# Altium schematic sheet main.SchDoc
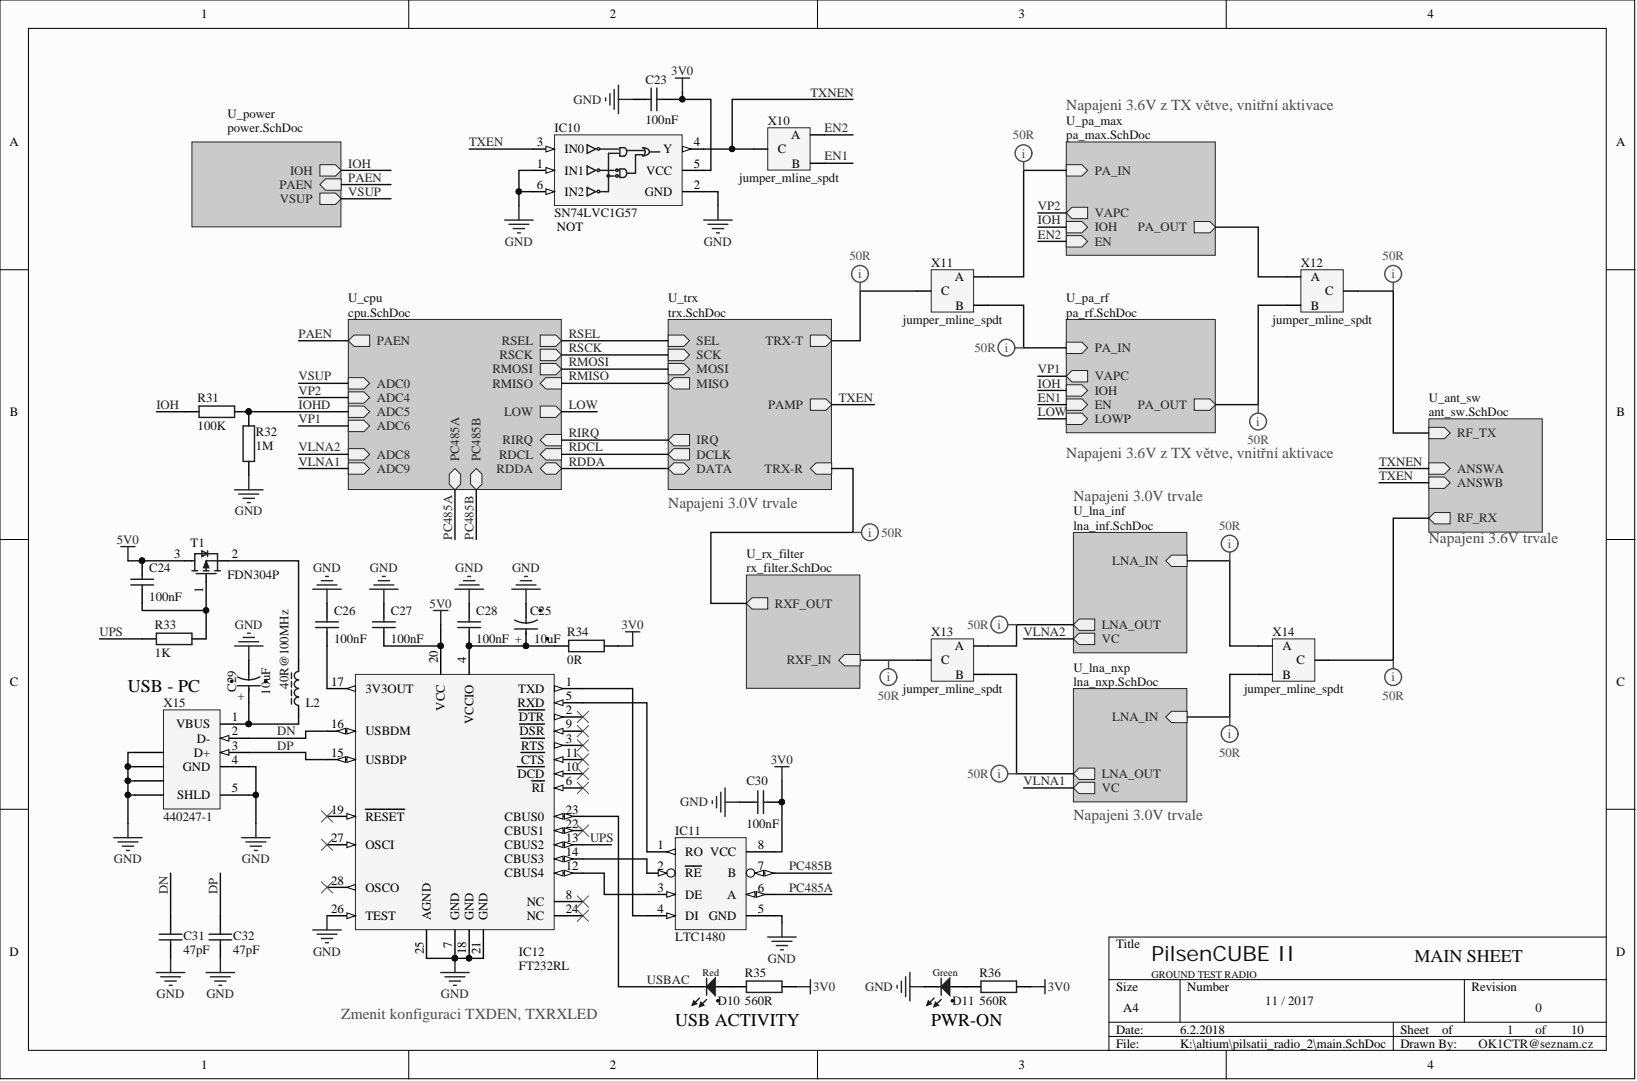
[diagram p0: main.SchDoc]

## Parts
C23  100nF  [C_0603]
C24  100nF  [C_0603]
C25  10uF  [CAPMP3216X180N]
C26  100nF  [C_0603]
C27  100nF  [C_0603]
C28  100nF  [C_0603]
C29  10uF  [CAPMP3216X180N]
C30  100nF  [C_0603]
C31  47pF  [C_0603]
C32  47pF  [C_0603]
D10  Red  [LED_DIALIGHT_551-1107F]
D11  Green  [LED_DIALIGHT_551-1307F]
IC10  SN74LVC1G57  [TSOP65P210X110-6N]
IC11  LTC1480  [SO-8_L]
IC12  FT232RL  [SSOP-28_L]
L2  40R@100MHz  [INDC2012X08N]
R31  100K  [R_0603]
R32  1M  [R_0603]
R33  1K  [R_0603]
R34  0R  [R_0603]
R35  560R  [R_0603]
R36  560R  [R_0603]
T1  FDN304P  [SOT95P251X93-3N]
X10  jumper_mline_spdt  [SPDT_MJMP_011]
X11  jumper_mline_spdt  [SPDT_MJMP_011]
X12  jumper_mline_spdt  [SPDT_MJMP_011]
X13  jumper_mline_spdt  [SPDT_MJMP_011]
X14  jumper_mline_spdt  [SPDT_MJMP_011]
X15  440247-1  [440247]

## Nets
3V0: C23.2 C30.2 IC10.5(VCC) IC11.8(VCC) R34.1 R35.1 R36.2
5V0: C24.1 C27.2 IC12.20(VCC) T1.3
GND: C23.1 C25.2 C26.1 C27.1 C28.1 C29.2 C30.1 C31.1 C32.1 D11.1 IC10.1(IN1) IC10.2(GND) IC10.6(IN2) IC11.5(GND) IC12.7(GND) IC12.18(GND) IC12.21(GND) IC12.25(AGND) IC12.26(TEST) R32.1 X15.4(GND) X15.5(SHLD) X15.6 X15.7 X15.8 X15.9
DN: C31.2 IC12.16(USBDM) X15.2(D-)
DP: C32.2 IC12.15(USBDP) X15.3(D+)
EN1: X10.2
EN2: X10.1
IOH: R31.2
IOHD: R31.1 R32.2
PC485A: IC11.6(A)
PC485B: IC11.7(B)
TXEN: IC10.3(IN0)
TXNEN: IC10.4(Y) X10.3
UPS: IC12.13(CBUS2) R33.2
USBAC: D10.1 IC12.23(CBUS0)
NetC24_2: C24.2 R33.1 T1.1
NetC25_1: C25.1 C28.2 IC12.4(VCCIO) R34.2
NetC26_2: C26.2 IC12.17(3V3OUT)
NetC29_1: C29.1 L2.1 X15.1(VBUS)
NetD10_2: D10.2 R35.2
NetD11_2: D11.2 R36.1
NetIC11_1: IC11.1(RO) IC12.5(RXD)
NetIC11_2: IC11.2(RE) IC12.14(CBUS3)
NetIC11_3: IC11.3(DE) IC12.12(CBUS4)
NetIC11_4: IC11.4(DI) IC12.1(TXD)
NetIC12_2: IC12.2(DTR)
NetIC12_3: IC12.3(RTS)
NetIC12_6: IC12.6(RI)
NetIC12_8: IC12.8(NC)
NetIC12_9: IC12.9(DSR)
NetIC12_10: IC12.10(DCD)
NetIC12_11: IC12.11(CTS)
NetIC12_19: IC12.19(RESET)
NetIC12_22: IC12.22(CBUS1)
NetIC12_24: IC12.24(NC)
NetIC12_27: IC12.27(OSCI)
NetIC12_28: IC12.28(OSCO)
NetL2_2: L2.2 T1.2
NetX11_1: X11.1
NetX11_2: X11.2
NetX11_3: X11.3
NetX12_1: X12.1
NetX12_2: X12.2
NetX12_3: X12.3
NetX13_1: X13.1
NetX13_2: X13.2
NetX13_3: X13.3
NetX14_1: X14.1
NetX14_2: X14.2
NetX14_3: X14.3
LOW:
PAEN:
RDCL:
RDDA:
RIRQ:
RMISO:
RMOSI:
RSCK:
RSEL:
RXF_OUT:
VLNA1:
VLNA2:
VP1:
VP2:
VSUP:

## Library data of the parts
C23 C24 C26-C28 C30-C32 = C: Generic Chip Capacitor; Type: SMT; Component Kind: Standard; PackageReference: C_0603; Published: 16.1.2018; Library Name: libctr2_capacitors.IntLib; Publisher: OK1CTR
  C23 C24 C26-C28 C30: Value: 100nF
  C31 C32: Value: 47pF
C25 C29 = CTE3216-18_10UF_10V_10%_LESR1800: Polarised capacitor; Manufacturer: GENERIC; Database Table Name: Capacitors SMD; Type: SMT; Case: A; Component Kind: Standard; Library Name: Capacitors.DbLib; Manuf Part Number: CTE3216-18_10UF_10V_10%_LESR1800; Published: 16.1.2018; Value: 10uF; Author: CERN DEM JLC; Publisher: OK1CTR
D10 = LED_DIALIGHT_551-1107F: LED Red 1C 2A; Database Table Name: LEDs & Displays; Type: THT; Color: Red; Component Kind: Standard; Library Name: ICs And Semiconductors.DbLib; Manufacturer: DIALIGHT; Manuf Part Number: 551-1107F; PackageReference: LED 3mm; Published: 16.1.2018; Value: Red; Author: CERN DEM JLC; Publisher: OK1CTR
D11 = LED_DIALIGHT_551-1307F: LED Green 1C 2A; Database Table Name: LEDs & Displays; Type: THT; Color: Green; Component Kind: Standard; Library Name: ICs And Semiconductors.DbLib; Manufacturer: DIALIGHT; Manuf Part Number: 551-1307F; PackageReference: LED 3mm; Published: 16.1.2018; Value: Green; Author: CERN DEM JLC; Publisher: OK1CTR
IC10 = (no symbol name): Configurable Multiple-Function Gate; Manufacturer: Texas Instruments; Manuf Part Number: SN74LVC1G57DCKR; Supp Part number: 1470833; Component Kind: Standard; ComponentLink1Description: Datasheet; Library Name: radio_power.SchLib; ManufacturerURL: http://www.ti.com/; PackageReference: SC-70; Published: 16.1.2018; Supplier: Farnell; Supplier2: N/A; Supplier3: N/A; SupplierURL: http://cz.farnell.com/; SupplierURL2: N/A; SupplierURL3: N/A; Supp Part number 2: N/A; Supp Part number 3: N/A; Type: SMT; Value: SN74LVC1G57; Publisher: OK1CTR
IC11 = LTC1480: Ultralow Power 3.3V RS485 Transceiver; Manufacturer: Linear Technology; Manuf Part Number: LTC1480CS8#PBF; Supp Part number: 1663633; Component Kind: Standard; ComponentLink1Description: Datasheet; ManufacturerURL: http://www.linear.com/; PackageReference: SOIC-8; Published: 16.1.2018; Supplier: Farnell; Supplier2: N/A; Supplier3: N/A; SupplierURL: http://cz.farnell.com; SupplierURL2: N/A; SupplierURL3: N/A; Supp Part number 2: N/A; Supp Part number 3: N/A; Type: SMT; Value: LTC1480; Library Name: libctr2_ics.IntLib; Publisher: OK1CTR
IC12 = FT232RL-Reel: USB UART Asynchronous Serial Data Transfer Chip, SSOP-28, Tape and Reel; Manufacturer: FTDI; Supp Part number: 1146032; Component Kind: Standard; ComponentLink1Description: Datasheet; Library Name: FTDI Communication UART.IntLib; ManufacturerURL: http://www.ftdichip.com; Manuf Part Number: FT232RL; PackageReference: SSOP-28; Published: 16.1.2018; Supplier: Farnell; Supplier2: N/A; Supplier3: N/A; SupplierURL: http://cz.farnell.com; SupplierURL2: N/A; SupplierURL3: N/A; Supp Part number 2: N/A; Supp Part number 3: N/A; Type: SMT; Value: FT232RL; Publisher: OK1CTR
L2 = (no symbol name): EMI; 0805; 40R/100MHz; 1.5A; 0.05R; Manufacturer: Laird Technologies; Manuf Part Number: MI0805K400R-10; Supp Part number: 2292459; Type: SMT; Component Kind: Standard; Library Name: radio_power.SchLib; ManufacturerURL: https://www.lairdtech.com/; PackageReference: L_0805; Published: 16.1.2018; Supplier: Farnell; Supplier2: N/A; Supplier3: N/A; SupplierURL: http://cz.farnell.com; SupplierURL2: N/A; SupplierURL3: N/A; Supp Part number 2: N/A; Supp Part number 3: N/A; Value: 40R@100MHz; Publisher: OK1CTR
R31-R36 = R: Generic Chip Resistor; Component Kind: Standard; PackageReference: R_0603; Published: 16.1.2018; Type: SMT; Library Name: libctr2_resistors.IntLib; Publisher: OK1CTR
  R31: Value: 100K
  R32: Value: 1M
  R33: Value: 1K
  R34: Value: 0R
  R35 R36: Value: 560R
T1 = (no symbol name): PMOS; 20V; 0.0.52Ohm; 12nC; Manufacturer: Fairchild; Supp Part number: 9846298; Type: SMT; Component Kind: Standard; ComponentLink1Description: Datasheet; Library Name: radio_power.SchLib; ManufacturerURL: http://www.fairchildsemi.com/; Manuf Part Number: FDN304P; PackageReference: SuperSOT; Published: 16.1.2018; Supplier: Farnell; Supplier2: N/A; Supplier3: N/A; SupplierURL: http://cz.farnell.com/; SupplierURL2: N/A; SupplierURL3: N/A; Supp Part number 2: N/A; Supp Part number 3: N/A; Value: FDN304P; Publisher: OK1CTR
X10-X14 = jumper_mline_spdt: SPDT Jumper for Microstrip Line; Component Kind: Standard; Published: 16.1.2018; Type: Planar; Library Name: libctr2_elmech.IntLib; Publisher: OK1CTR
X15 = 440247-1: USB 2.0, Right Angle, SMT, B Type, Receptacle, 5 Position, Black; Manufacturer: TE Connectivity; Manuf Part Number: 1734035-1; Supp Part number: 1654060; Color: http://www.te.com; Component Kind: Standard; ComponentLink1Description: Datasheet; Published: 16.1.2018; Signal Integrity: USB2.0; Supplier: Farnell; Supplier2: N/A; Supplier3: N/A; SupplierURL: http://cz.farnell.com; SupplierURL2: N/A; SupplierURL3: N/A; Supp Part number 2: N/A; Supp Part number 3: N/A; Type: SMT; Library Name: libctr2_elmech.IntLib; Publisher: OK1CTR
